# Altium schematic sheet STM32_40_DK.SchDoc(STM32_40_DK)
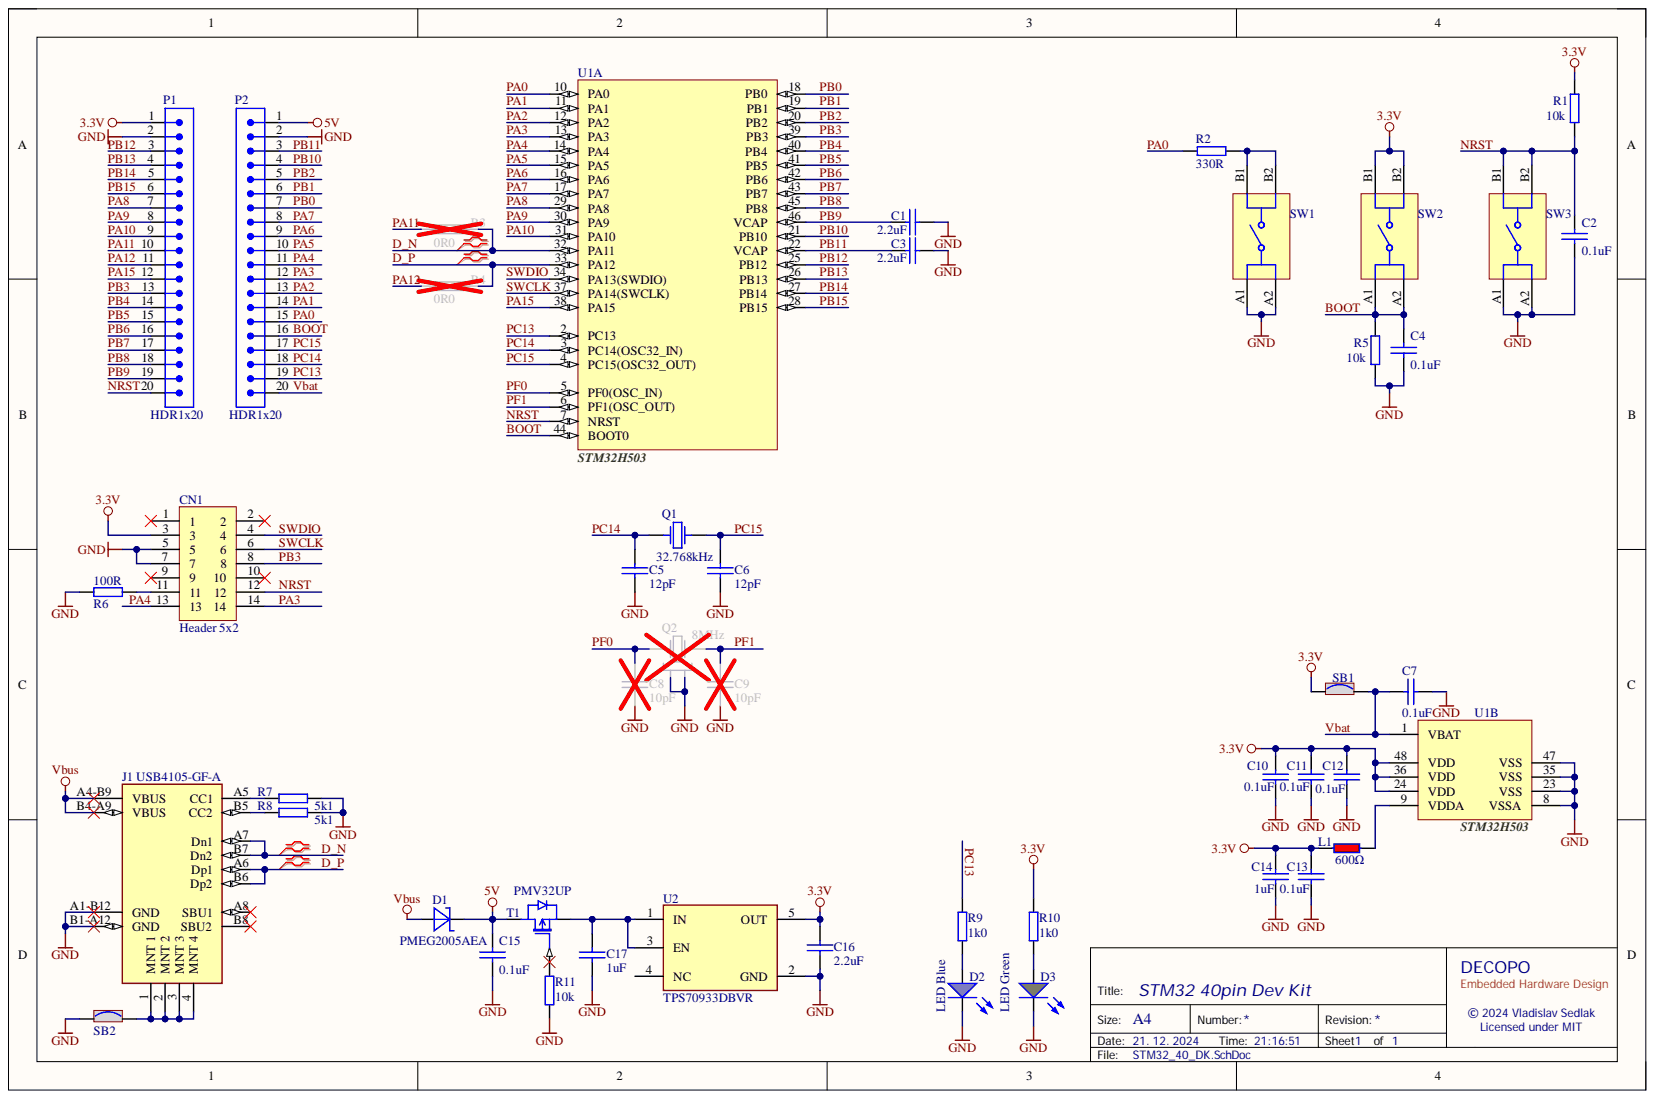
[diagram p0: STM32_40_DK.SchDoc]

## Parts
C1  2.2uF  [FP-C1005-050-0_05-IPC_B]
C2  0.1uF  [FP-C1005-050-0_05-IPC_B]
C3  2.2uF  [FP-C1005-050-0_05-IPC_B]
C4  0.1uF  [FP-C1005-050-0_05-IPC_B]
C5  12pF  [FP-C1005-050-0_05-IPC_B]
C6  12pF  [FP-C1005-050-0_05-IPC_B]
C7  0.1uF  [FP-C1005-050-0_05-IPC_B]
C8  10pF  [FP-C1005-050-0_05-IPC_B]
C9  10pF  [FP-C1005-050-0_05-IPC_B]
C10  0.1uF  [FP-C1005-050-0_05-IPC_B]
C11  0.1uF  [FP-C1005-050-0_05-IPC_B]
C12  0.1uF  [FP-C1005-050-0_05-IPC_B]
C13  0.1uF  [FP-C1005-050-0_05-IPC_B]
C14  1uF  [FP-C1005-050-0_05-IPC_B]
C15  0.1uF  [FP-C1005-050-0_05-IPC_B]
C16  2.2uF  [FP-C1005-050-0_05-IPC_B]
C17  1uF  [FP-C1005-050-0_05-IPC_B]
CN1  value Header 5x2  [CON_STDC14_Receiver_MEDIUM_WITH_MIPI10_3D]  (hidden comment: Header 7x2)
D1  PMEG2005AEA  [SOD-323F]
D2  LED Blue  Emitting Color: Blue  PartType: LED  [WL-SMCW_0603_150060xx75000]
D3  LED Green  Emitting Color: Green  PartType: LED  [WL-SMCW_0603_150060xx75000]
J1  USB4105-GF-A  [FP-USB4105-GF-A-MFG]
L1  600Ω  [FP-BLM15-0_05-IPC_B]
P1  HDR1x20  [pin hdr 2.54 1x20]
P2  HDR1x20  [pin hdr 2.54 1x20]
Q1  32.768kHz  [FP-ECX-34Q-MFG]
Q2  8MHz  [XTAL 3225]
R1  10k  [FP-CRCW0402-e3-IPC_B]
R2  330R  [FP-CRCW0402-e3-IPC_B]
R3  0R0  [FP-CRCW0402-e3-IPC_B]
R4  0R0  [FP-CRCW0402-e3-IPC_B]
R5  10k  [FP-CRCW0402-e3-IPC_B]
R6  100R  [FP-CRCW0402-e3-IPC_B]
R7  5k1  [FP-CRCW0402-e3-IPC_B]
R8  5k1  [FP-CRCW0402-e3-IPC_B]
R9  1k0  [FP-CRCW0402-e3-IPC_B]
R10  1k0  [FP-CRCW0402-e3-IPC_B]
R11  10k  [FP-CRCW0402-e3-IPC_B]
SB1  SB_ON  [SOLDER-BRIDGE_R0603-0R]  (value not drawn)
SB2  SB_ON  [SOLDER-BRIDGE_R0603-0R]  (value not drawn)
SW1  434133025816  [434133025816]  (value not drawn)
SW2  434133025816  [434133025816]  (value not drawn)
SW3  434133025816  [434133025816]  (value not drawn)
T1  PMV32UP  [SOT-23]
U1  STM32H503  [LQFP48_L]  (parts A,B)
U2  TPS70933DBVR  Output Voltage: 3.3V  [SOT23-5_M]

## Nets
3.3V: C10.1 C11.1 C12.1 C13.1 C14.2 C16.2 CN1.3 L1.2 P1.1 R1.2 R10.1 SB1.1 SW2.B1 SW2.B2 U1.24(VDD) U1.36(VDD) U1.48(VDD) U2.5(OUT)
5V: C15.1 D1.1 P2.1 T1.3
GND: C1.1 C2.2 C3.1 C4.2 C5.1 C6.1 C7.2 C8.1 C9.1 C10.2 C11.2 C12.2 C13.2 C14.1 C15.2 C16.1 C17.1 CN1.5 CN1.7 D2.1 D3.1 J1.A1-B12(GND) J1.B1-A12(GND) P1.2 P2.2 Q2.2 Q2.4 R5.1 R6.1 R7.2 R8.2 R11.1 SB2.1 SW1.A1 SW1.A2 SW3.A1 SW3.A2 U1.8(VSSA) U1.23(VSS) U1.35(VSS) U1.47(VSS) U2.2(GND)
Vbus: D1.2 J1.A4-B9(VBUS) J1.B4-A9(VBUS)
BOOT: C4.1 P2.16 R5.2 SW2.A1 SW2.A2 U1.44(BOOT0)
D_N: J1.A7(Dn1) J1.B7(Dn2) R3.2 U1.32(PA11)
D_P: J1.A6(Dp1) J1.B6(Dp2) R4.2 U1.33(PA12)
NRST: C2.1 CN1.12 P1.20 R1.1 SW3.B1 SW3.B2 U1.7(NRST)
PA0: P2.15 R2.1 U1.10(PA0)
PA1: P2.14 U1.11(PA1)
PA2: P2.13 U1.12(PA2)
PA3: CN1.14 P2.12 U1.13(PA3)
PA4: CN1.13 P2.11 U1.14(PA4)
PA5: P2.10 U1.15(PA5)
PA6: P2.9 U1.16(PA6)
PA7: P2.8 U1.17(PA7)
PA8: P1.7 U1.29(PA8)
PA9: P1.8 U1.30(PA9)
PA10: P1.9 U1.31(PA10)
PA11: P1.10 R3.1
PA12: P1.11 R4.1
PA15: P1.12 U1.38(PA15)
PB0: P2.7 U1.18(PB0)
PB1: P2.6 U1.19(PB1)
PB2: P2.5 U1.20(PB2)
PB3: CN1.8 P1.13 U1.39(PB3)
PB4: P1.14 U1.40(PB4)
PB5: P1.15 U1.41(PB5)
PB6: P1.16 U1.42(PB6)
PB7: P1.17 U1.43(PB7)
PB8: P1.18 U1.45(PB8)
PB9: C1.2 P1.19 U1.46(VCAP)
PB10: P2.4 U1.21(PB10)
PB11: C3.2 P2.3 U1.22(VCAP)
PB12: P1.3 U1.25(PB12)
PB13: P1.4 U1.26(PB13)
PB14: P1.5 U1.27(PB14)
PB15: P1.6 U1.28(PB15)
PC13: P2.19 R9.1 U1.2(PC13)
PC14: C5.2 P2.18 Q1.1 U1.3(PC14(OSC32_IN))
PC15: C6.2 P2.17 Q1.2 U1.4(PC15(OSC32_OUT))
PF0: C8.2 Q2.1 U1.5(PF0(OSC_IN))
PF1: C9.2 Q2.3 U1.6(PF1(OSC_OUT))
SWCLK: CN1.6 U1.37(PA14(SWCLK))
SWDIO: CN1.4 U1.34(PA13(SWDIO))
Vbat: C7.1 P2.20 SB1.2 U1.1(VBAT)
NetC17_2: C17.2 T1.2 U2.1(IN) U2.3(EN)
NetCN1_11: CN1.11 R6.2
NetD2_2: D2.2 R9.2
NetD3_2: D3.2 R10.2
NetJ1_1: J1.1(MNT_1) J1.2(MNT_2) J1.3(MNT_3) J1.4(MNT_4) SB2.2
NetJ1_A5: J1.A5(CC1) R7.1
NetJ1_B5: J1.B5(CC2) R8.1
NetL1_1: L1.1 U1.9(VDDA)
NetR2_2: R2.2 SW1.B1 SW1.B2
NetR11_2: R11.2 T1.1

Not connected: CN1 1 2 9 10; J1 A8(SBU1) B8(SBU2); U2 4(NC)

## Library data of the parts
C1 C3 C16 = 76: Capacitor 2.2uF 0402 16V X5R 20%; Database Table Name: Capacitors$; Component Kind: Standard; Dielectric: X5R; Max Operating Temperature: 85°C; Min Operating Temperature: -55°C; Package: 0402; PartType: Capacitor; Tolerance: 20%; Unique: 76; Value: 2.2uF; Voltage Rating: 16V; Library Name: pasive.DbLib
C2 C4 C7 C10-C13 C15 = 63: Capacitor 0.1uF 0402 16V X7R 10%; Database Table Name: Capacitors$; Component Kind: Standard; Dielectric: X7R; Max Operating Temperature: 125°C; Min Operating Temperature: -55°C; Package: 0402; PartType: Capacitor; Tolerance: 10%; Unique: 63; Value: 0.1uF; Voltage Rating: 16V; Library Name: pasive.DbLib
C5 C6 = 18: Capacitor 12pF 0402 50V NP0 5%; Database Table Name: Capacitors$; Component Kind: Standard; Dielectric: NP0; Max Operating Temperature: 125°C; Min Operating Temperature: -55°C; Package: 0402; PartType: Capacitor; Tolerance: 5%; Unique: 18; Value: 12pF; Voltage Rating: 50V; Library Name: pasive.DbLib
C8 C9 = 17: Capacitor 10pF 0402 50V NP0 5%; Database Table Name: Capacitors$; Component Kind: Standard; Dielectric: NP0; Max Operating Temperature: 125°C; Min Operating Temperature: -55°C; Package: 0402; PartType: Capacitor; Tolerance: 5%; Unique: 17; Value: 10pF; Voltage Rating: 50V; Library Name: pasive.DbLib
C14 C17 = 75: Capacitor 1uF 0402 16V X5R 20%; Database Table Name: Capacitors$; Component Kind: Standard; Dielectric: X5R; Max Operating Temperature: 85°C; Min Operating Temperature: -55°C; Package: 0402; PartType: Capacitor; Tolerance: 20%; Unique: 75; Value: 1uF; Voltage Rating: 16V; Library Name: pasive.DbLib
CN1 = Header 7x2: Header, 5x2, 1.27mm, VR, SMD, T=5.97mm/3.05mm; Internal_Part_Number: MCD-01226; Manufacturer: SAMTEC; Manufacturer_Part_Number: FTSH-105-01-L-DV-K; Part_Preference: Recommended; Part_Type: Connector; Component_Country_Of_Origin: China, Costa Rica, Malaysia, United States, Vietnam; ID: 3265; Order_Code_RS: 159-2332; ShowFields: YES; Component Kind: Standard; ComponentLink1URL: http://tunmcdsvn.tun.st.com/Component_Documentation_Library/Trunk/Connector/SAMTEC_FTSH_Series/; Library Name: connector.SchLib; Order_Code_Digikey: SAM8799-ND; Order_Code_Farnell: 2856879; Status: Released
D1 = 18: PMEG2005AEA, Schottky Barrier Diode, SOD323; Database Table Name: Schottky$; Leakage Current (Vr = 50V): 40uA; Max# average forward rectified current: 500mA; Max# instantaneous forward voltage: 0.355V; Max# repetitive peak reverse voltage: 20V; Component Kind: Standard; Library Name: discrete.DbLib; Max Operating Temperature: 150°C; Min Operating Temperature: -55°C; Package: SOD323; PartType: Schottky Barrier Diode; Unique: 18; Value: PMEG2005AEA
D2 = 6: LED Blue 145mcd 0603 140°; Manufacturer: WE; ManufacturerPartNumber: 150060BS75000; Chip Techmology: InGaN; Database Table Name: LED$; Vf typ: 3,2V; Wavelength: 470nm; Angle: 140°; Component Kind: Standard; Iv typ: 145mcd; Library Name: led.DbLib; Max Operating Temperature: 85°C; Min Operating Temperature: -40°C; Package: 0603; Unique: 6; Value: LED Blue
D3 = 5: LED Green 430mcd 0603 140°; Manufacturer: WE; ManufacturerPartNumber: 150060GS75000; Chip Techmology: InGaN; Database Table Name: LED$; Vf typ: 3,2V; Wavelength: 525nm; Angle: 140°; Component Kind: Standard; Iv typ: 430mcd; Library Name: led.DbLib; Max Operating Temperature: 85°C; Min Operating Temperature: -40°C; Package: 0603; Unique: 5; Value: LED Green
J1 = USB4105-GF-A: CONN RCPT USB2.0 TYPEC 5A; Manufacturer: GCT; Conn Orientation: Right Angle; Current Rating: 5/6.25/1.25/0.25A; Is Female: yes; Number Of Contacts: 16; Voltage Rating: 20V; Automotive: No; Category: Conn; Component Kind: Standard; ComponentLink2Description: Datasheet; ComponentLink2URL: https://gct.co/files/drawings/usb4105.pdf; Contact Material: Gold,Nickel; Device Class L1: Connectors; Device Class L2: USB Connectors; Device Class L3: unset; Digikey Description: CONN RCPT USB2.0 TYPEC 5A; Height: 3.46mm; Lead Free: Yes; Library Name: connector.SchLib; Manufacturer Part Number: USB4105-GF-A; Octopart: https://octopart.com/usb4105-gf-a-gct-106627878; Package: 0; RoHS: TRUE; Temperature: -40°C to +85°C; Temperature Range High: +85°C; Temperature Range Low: -40°C; Usb Standard: USB 2.0
L1 = 1: Ferrite Bead 600Ω@100MHz 0402 500mA DCR=340mΩ; Database Table Name: Ferrite Beads$; DC Resistance: 340mΩ; TestFrequency: 100MHz; Component Kind: Standard; Current Rating: 500mA; Max Operating Temperature: 125°C; Min Operating Temperature: -55°C; Package: 0402; PartType: Ferrite Bead; Unique: 1; Value: 600Ω; Library Name: pasive.DbLib
P1 P2 = 15: Header Male 1x20 2.54mm Gold THT; Database Table Name: Pinhead$; MountingAngle: Straight; Rows: 1; Component Kind: Standard; ContactPlating: Gold; Gender: Male; Library Name: connector.DbLib; Max Operating Temperature: 125°C; Min Operating Temperature: -55°C; MountingStyle: THT; PartType: Header; Pins: 20; Pitch: 2.54mm; Unique: 15; Value: HDR1x20
Q1 = 3: Crystal 32.768kHz 7pF +-20PPM 3216; Manufacturer: ABRACON; ManufacturerPartNumber: ABS07-32.768KHZ-7-T; Database Table Name: Xtall$; Tolerance: 20PPM; Component Kind: Standard; Load Capacitance: 7pF; Max Operating Temperature: 85°C; Min Operating Temperature: -40°C; Package: 3216; PartType: Crystal; Unique: 3; Value: 32.768kHz; Library Name: pasive.DbLib
Q2 = 4: Crystal 8MHz 20pF +-20PPM 3225; Database Table Name: Xtall$; Tolerance: 20PPM; Component Kind: Standard; Load Capacitance: 20pF; Max Operating Temperature: 70°C; Min Operating Temperature: -20°C; Package: 3225; PartType: Crystal; Unique: 4; Value: 8MHz; Library Name: pasive.DbLib
R1 R5 R11 = 51: Resistor 10k 0402 1% 63.5mW; Database Table Name: Resistors$; Temperature Coefficient: 100ppm/°C; Voltage Rating: 50V; Component Kind: Standard; Max Operating Temperature: 155°C; Max Power Dissipation: 63.5mW; Min Operating Temperature: -55°C; Package: 0402; PartType: Resistor; Tolerance: 1%; Unique: 51; Value: 10k; Library Name: pasive.DbLib
R2 = 32: Resistor 330R 0402 1% 63.5mW; Database Table Name: Resistors$; Temperature Coefficient: 100ppm/°C; Voltage Rating: 50V; Component Kind: Standard; Max Operating Temperature: 155°C; Max Power Dissipation: 63.5mW; Min Operating Temperature: -55°C; Package: 0402; PartType: Resistor; Tolerance: 1%; Unique: 32; Value: 330R; Library Name: pasive.DbLib
R3 R4 = 1: Resistor 0R0 0402 1% 63.5mW; Database Table Name: Resistors$; Temperature Coefficient: 100ppm/°C; Voltage Rating: 50V; Component Kind: Standard; Max Operating Temperature: 155°C; Max Power Dissipation: 63.5mW; Min Operating Temperature: -55°C; Package: 0402; PartType: Resistor; Tolerance: 1%; Unique: 1; Value: 0R0; Library Name: pasive.DbLib
R6 = 26: Resistor 100R 0402 1% 63.5mW; Database Table Name: Resistors$; Temperature Coefficient: 100ppm/°C; Voltage Rating: 50V; Component Kind: Standard; Max Operating Temperature: 155°C; Max Power Dissipation: 63.5mW; Min Operating Temperature: -55°C; Package: 0402; PartType: Resistor; Tolerance: 1%; Unique: 26; Value: 100R; Library Name: pasive.DbLib
R7 R8 = 47: Resistor 5k1 0402 1% 63.5mW; Database Table Name: Resistors$; Temperature Coefficient: 100ppm/°C; Voltage Rating: 50V; Component Kind: Standard; Max Operating Temperature: 155°C; Max Power Dissipation: 63.5mW; Min Operating Temperature: -55°C; Package: 0402; PartType: Resistor; Tolerance: 1%; Unique: 47; Value: 5k1; Library Name: pasive.DbLib
R9 R10 = 38: Resistor 1k0 0402 1% 63.5mW; Database Table Name: Resistors$; Temperature Coefficient: 100ppm/°C; Voltage Rating: 50V; Component Kind: Standard; Max Operating Temperature: 155°C; Max Power Dissipation: 63.5mW; Min Operating Temperature: -55°C; Package: 0402; PartType: Resistor; Tolerance: 1%; Unique: 38; Value: 1k0; Library Name: pasive.DbLib
SB1 SB2 = SB_ON: Solder Bridge ON; Internal_Part_Number: MCD-00071; Manufacturer: YAGEO; Manufacturer_Part_Number: RC0603JR-070RL; Part_Type: Mechanical; Provided_By: SUBCO; Component Kind: Standard; ComponentLink1Description: Datasheet; ComponentLink1URL: http://tunmcdsvn.tun.st.com/Component_Datasheet_Library/Trunk/Resistors/Yageo_Resistor_RC0603-series; ECCN_EU: n/a; ECCN_US: n/a; Library Name: mechanical.SchLib; Package: SB0603; Status: Released
SW1-SW3 = SPST-NO_4P: Tactile Switch, 0.05A, 16Vdc, 160Gf, Smd Rohs Compliant: Yes; Manufacturer: Wurth Elektronik; Match Code: WS-TASV; Operation Force: 160g; Rated Current: 50mA; Rated Voltage (DC): 12V; Washable: No; Category: Tact Switches; Circuit: SPST-NO; Component Kind: Standard; ComponentLink1Description: Website Link; ComponentLink1URL: https://www.we-online.com/redexpert/article/434121043816?ad; ComponentLink2Description: Datasheet Link; ComponentLink2URL: https://www.we-online.com/redexpert/spec/434121043816?ad; Contact Material: Stainless Steel; Contact Plating: Silver; Height: 4.3mm; Length: 6mm; Library Name: mechanical.SchLib; Manufacturer Part Number: 434133025816; Mount: Surface Mount; Operating Temperature Max: 85°C; Operating Temperature Min: -40°C; Packaging: Tape and Reel; Terminal Material: Copper Alloy; Terminal Plating: Silver; Width: 3.5mm
T1 = 195: PMV32UP -20 P-MOSFET, SOT23; Manufacturer: Nexperia; Database Table Name: MOSFET$; DescriptionSk: Tranzistor MOSFET s P kanálom; ESD Diode: No; Id: -5,4; Pd: 250mW; Polarity: P; Qg: 15,5; Rds(ON) @ Vgs=1#8V: 57; Rds(ON) @ Vgs=2#5V: 42; Rds(ON) @ Vgs=4#5V: 34; Toff typ#: 128; VGS: 8; VGS(th) max: 0,95; VGS(th) min: 0,45; Component Kind: Standard; Library Name: discrete.DbLib; ManufacturerPartNumber: PMV32UP; Max Operating Temperature: 150°C; Min Operating Temperature: -55°C; Package: SOT23; PackageType: SMT; PartType: MOSFET; Schottky Diode: No; Ton typ#: 34; Unique: 195; Value: PMV32UP; Vds: -20
U1 = STM32XxxxCxx: Low-Power ARM Cortex; Manufacturer: STMicroelectronics; PartNumber: STM32L476VET6; Core: ARM Cortex-M4; D/A Converter: 2; FLASH Size (Prog) (kB): 512; I/Os (High Current): 82; Internal RAM Size (kB): 128; Operating Frequency(F) (Processor speed) (MHz): 80; Packing: Tray; Supply Voltage(Vcc) min (V): 1.71; Component Kind: Standard; ComponentLink1Description: Manufacturer URL; ComponentLink1URL: http://www.st.com/; ComponentLink2Description: Datasheet; ComponentLink2URL: http://www.st.com/st-web-ui/static/active/en/resource/technical/document/datasheet/DM00108832.pdf; DatasheetVersion: Rev. 4; Library Name: ic.SchLib; Mounting Technology: Surface Mount; Package Description: 100-Pin Low Profile Quad Flat Package, Body 14 x 14 mm, Pitch 0.5 mm; Package Reference: LQFP100
U2 = TPS70933DBV: IC REG LINEAR 3.3V 150MA SOT23-5; Accuracy: 2%; Dropout Voltage: 975mV; Max Input Voltage: 30V; Min Current Limit: 200mA; Min Input Voltage: 2.7V; Number of Outputs: 1; Output Type: Fixed; Polarity: Positive; Power Supply Rejection Ratio (PSRR): 52dB; Quiescent Current: 10uA; Case/Package: SOT-23-5; Component Kind: Standard; Contact Plating: Gold; Lead Free: Lead Free; Library Name: ic.SchLib; Max Operating Temperature: 125°C; Max Output Current: 150mA; Min Operating Temperature: -40°C; Mount: Surface Mount; Number of Pins: 5; Number of Regulators: 1; Output Current: 150mA; Package Quantity: 3000; Packaging: Tape and Reel; RoHS Compliant: Yes
